# Altium schematic sheet display.SchDoc(display)
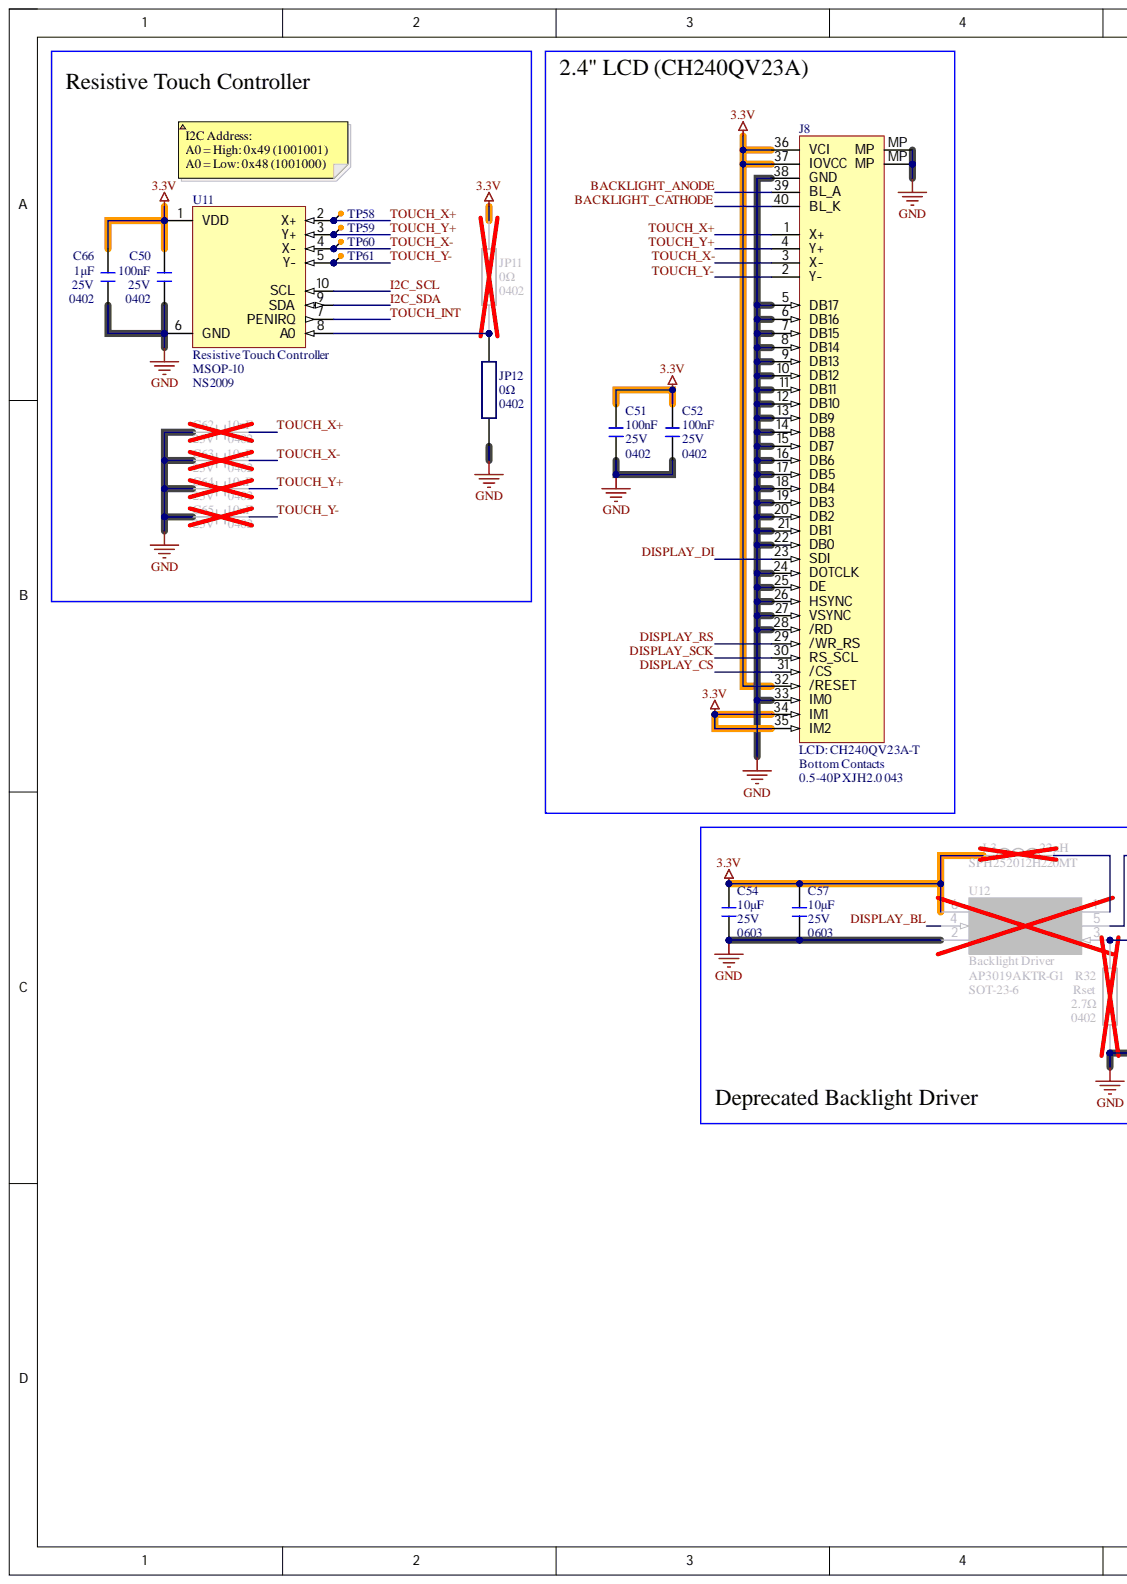
[diagram p0: display.SchDoc, left]
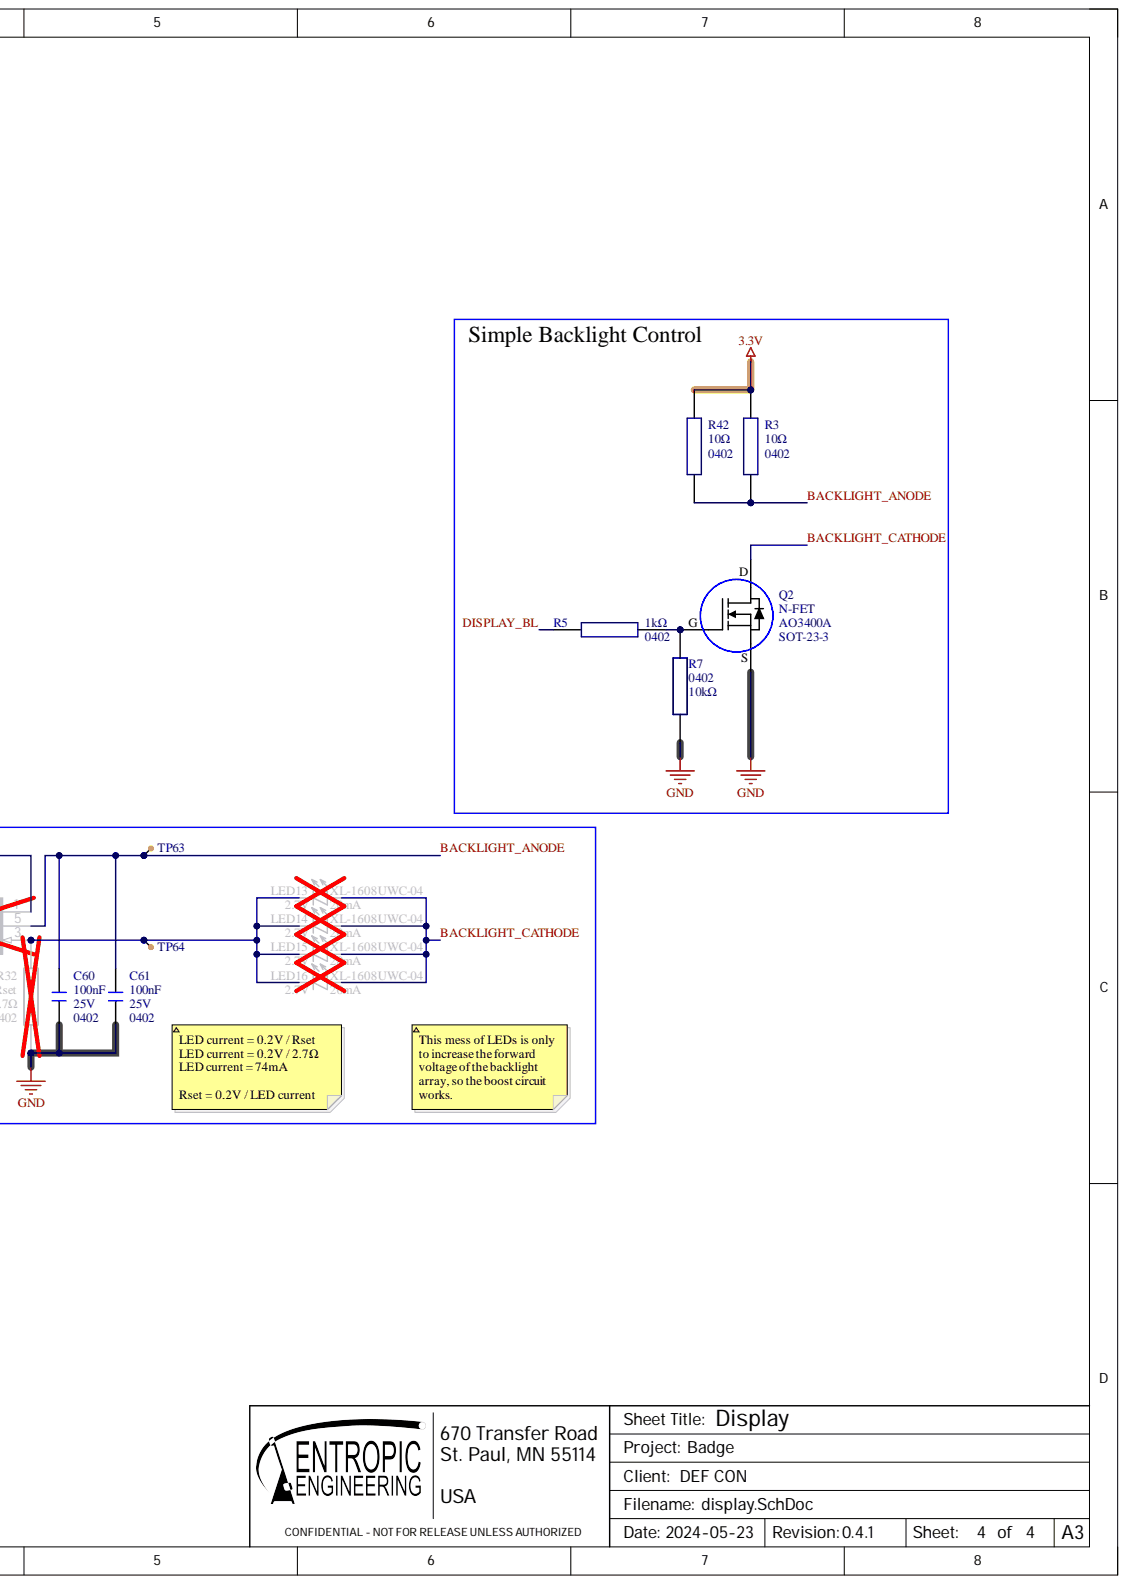
[diagram p1: display.SchDoc, right]

## Parts
C50  Capacitance: 100nF  Case Code (Imperial): 0402  Voltage: 25V  [0402_capacitor]  (hidden comment: GRM155R71E104KE14D)
C51  Capacitance: 100nF  Case Code (Imperial): 0402  Voltage: 25V  [0402_capacitor]  (hidden comment: GRM155R71E104KE14D)
C52  Capacitance: 100nF  Case Code (Imperial): 0402  Voltage: 25V  [0402_capacitor]  (hidden comment: GRM155R71E104KE14D)
C54  value 10µF  Case Code (Imperial): 0603  Voltage Rating: 25V  [0603_capacitor]  (hidden comment: CL10A106MA8NRNC)
C57  value 10µF  Case Code (Imperial): 0603  Voltage Rating: 25V  [0603_capacitor]  (hidden comment: CL10A106MA8NRNC)
C60  Capacitance: 100nF  Case Code (Imperial): 0402  Voltage: 25V  [0402_capacitor]  (hidden comment: GRM155R71E104KE14D)
C61  Capacitance: 100nF  Case Code (Imperial): 0402  Voltage: 25V  [0402_capacitor]  (hidden comment: GRM155R71E104KE14D)
C62  Capacitance: 10nF  Case Code (Imperial): 0402  Voltage Rating: 25V  [0402_capacitor]  (hidden comment: CL05B103KA5NNNC)
C63  Capacitance: 10nF  Case Code (Imperial): 0402  Voltage Rating: 25V  [0402_capacitor]  (hidden comment: CL05B103KA5NNNC)
C64  Capacitance: 10nF  Case Code (Imperial): 0402  Voltage Rating: 25V  [0402_capacitor]  (hidden comment: CL05B103KA5NNNC)
C65  Capacitance: 10nF  Case Code (Imperial): 0402  Voltage Rating: 25V  [0402_capacitor]  (hidden comment: CL05B103KA5NNNC)
C66  Capacitance: 1µF  Size Code: 0402  Voltage: 25V  [0402_capacitor]  (hidden comment: GRM155R61E105KA12D)
J8  LCD: CH240QV23A-T  contact_side: Bottom Contacts  [CH240QV23A-T]
JP11  value 0Ω  Case Code (Imperial): 0402  [0402_resistor]  (hidden comment: RC0402FR-070RL)
JP12  value 0Ω  Case Code (Imperial): 0402  [0402_resistor]  (hidden comment: RC0402FR-070RL)
L3  SPH252012H220MT  value 22µH  [INDC2520X12N]
LED13  XL-1608UWC-04  Current: 20mA  Forward Voltage: 2.7V  [0603_DIODE]
LED14  XL-1608UWC-04  Current: 20mA  Forward Voltage: 2.7V  [0603_DIODE]
LED15  XL-1608UWC-04  Current: 20mA  Forward Voltage: 2.7V  [0603_DIODE]
LED16  XL-1608UWC-04  Current: 20mA  Forward Voltage: 2.7V  [0603_DIODE]
Q2  N-FET  Case/Package: SOT-23-3  [SOT95P280X115-3N]
R3  value 10Ω  Case Code (Imperial): 0402  [0402_resistor]  (hidden comment: RC0402JR-0710RL)
R5  value 1kΩ  Case Code (Imperial): 0402  [0402_resistor]  (hidden comment: RC0402JR-071KL)
R7  value 10kΩ  Case Code (Imperial): 0402  [0402_resistor]  (hidden comment: RC0402FR-0710KL)
R32  Rset  value 2.7Ω  Case Code (Imperial): 0402  [0402_resistor]
R42  value 10Ω  Case Code (Imperial): 0402  [0402_resistor]  (hidden comment: RC0402JR-0710RL)
TP58  test_point-1  [test_point-1]  (value not drawn)  (no BOM)
TP59  test_point-1  [test_point-1]  (value not drawn)  (no BOM)
TP60  test_point-1  [test_point-1]  (value not drawn)  (no BOM)
TP61  test_point-1  [test_point-1]  (value not drawn)  (no BOM)
TP63  test_point-1  [test_point-1]  (value not drawn)  (no BOM)
TP64  test_point-1  [test_point-1]  (value not drawn)  (no BOM)
U11  Resistive Touch Controller  Case/Package: MSOP-10  [TSSOP50P490X112-10N]
U12  Backlight Driver  Case/Package: SOT-23-6  [SOT95P280X110-6N]

## Nets
3.3V: C50.2 C51.2 C52.2 C54.2 C57.2 C66.2 J8.32(/RESET) J8.34(IM1) J8.35(IM2) J8.36(VCI) J8.37(IOVCC) JP11.1 L3.1 R3.2 R42.2 U11.1(VDD) U12.6(VIN)
GND: C50.1 C51.1 C52.1 C54.1 C57.1 C60.1 C61.1 C62.1 C63.1 C64.1 C65.1 C66.1 J8.5(DB17) J8.6(DB16) J8.7(DB15) J8.8(DB14) J8.9(DB13) J8.10(DB12) J8.11(DB11) J8.12(DB10) J8.13(DB9) J8.14(DB8) J8.15(DB7) J8.16(DB6) J8.17(DB5) J8.18(DB4) J8.19(DB3) J8.20(DB2) J8.21(DB1) J8.22(DB0) J8.24(DOTCLK) J8.25(DE) J8.26(HSYNC) J8.27(VSYNC) J8.28(/RD) J8.33(IM0) J8.38(GND) J8.MP JP12.2 Q2.2 R7.2 R32.2 U11.6(GND) U12.2(GND)
BACKLIGHT_ANODE: C60.2 C61.2 J8.39(BL_A) R3.1 R42.1 TP63.1 U12.5(VOUT)
BACKLIGHT_CATHODE: J8.40(BL_K) LED13.2 LED14.2 LED15.2 LED16.2 Q2.3
DISPLAY_BL: R5.1 U12.4(CTRL)
DISPLAY_CS: J8.31(/CS)
DISPLAY_DI: J8.23(SDI)
DISPLAY_RS: J8.29(/WR_RS)
DISPLAY_SCK: J8.30(RS_SCL)
I2C_SCL: U11.10(SCL)
I2C_SDA: U11.9(SDA)
TOUCH_INT: U11.7(PENIRQ)
TOUCH_X+: C62.2 J8.1(X+) TP58.1 U11.2(X+)
TOUCH_X-: C63.2 J8.3(X-) TP60.1 U11.4(X-)
TOUCH_Y+: C64.2 J8.4(Y+) TP59.1 U11.3(Y+)
TOUCH_Y-: C65.2 J8.2(Y-) TP61.1 U11.5(Y-)
NetJP11_2: JP11.2 JP12.1 U11.8(A0)
NetL3_2: L3.2 U12.1(SW)
NetLED13_1: LED13.1 LED14.1 LED15.1 LED16.1 R32.1 TP64.1 U12.3(FB)
NetQ2_1: Q2.1 R5.2 R7.1

## Library data of the parts
C50-C52 C60 C61 = GRM155R71E104KE14D: 100 nF, 25 V, X7R, 0402 Chip capacitor; house_part_number: C-100nF-0402-002; Manufacturer: Murata; Dielectric Material: Ceramic; Tolerance: 10%; Case Code (Metric): 1005; Case/Package: 0402; Category: Ceramic Capacitors; Component Kind: Standard; ComponentLink1Description: http://www.farnell.com/datasheets/1872206.pdf?src-supplier=Farnell; ComponentLink1URL: http://www.farnell.com/datasheets/1872206.pdf?src-supplier=Farnell; ComponentLink2Description: http://components.arrow.com/part/dataview/0323_06361249?src-supplier=Arrow+Europe; ComponentLink2URL: http://components.arrow.com/part/dataview/0323_06361249?src-supplier=Arrow+Europe; ComponentLink3Description: http://uk.rs-online.com/webdocs/144c/0900766b8144c113.pdf?src-supplier=RSComponents; ComponentLink3URL: http://uk.rs-online.com/webdocs/144c/0900766b8144c113.pdf?src-supplier=RSComponents; ComponentLink4Description: http://www.farnell.com/datasheets/2048008.pdf?src-supplier=Farnell; ComponentLink4URL: http://www.farnell.com/datasheets/2048008.pdf?src-supplier=Farnell; ComponentLink5Description: http://www.mouser.com/ds/2/281/c02e-2905.pdf?src-supplier=Mouser; ComponentLink5URL: http://www.mouser.com/ds/2/281/c02e-2905.pdf?src-supplier=Mouser; ComponentLink6Description: http://www.farnell.com/datasheets/2048008.pdf?src-supplier=Newark; ComponentLink6URL: http://www.farnell.com/datasheets/2048008.pdf?src-supplier=Newark; ComponentLink7Description: https://search.murata.co.jp/Ceramy/image/img/A01X/G101/ENG/GRM155R71E104KE14-01.pdf?src-supplier=Digi-Key; ComponentLink7URL: https://search.murata.co.jp/Ceramy/image/img/A01X/G101/ENG/GRM155R71E104KE14-01.pdf?src-supplier=Digi-Key; ComponentLink8Description: http://www.farnell.com/datasheets/2048008.pdf?src-supplier=Element14; ComponentLink8URL: http://www.farnell.com/datasheets/2048008.pdf?src-supplier=Element14; ComponentLink9Description: http://psearch.en.murata.com/capacitor/product/GRM155R71E104KE14%23.pdf?src-supplier=Avnet; ComponentLink9URL: http://psearch.en.murata.com/capacitor/product/GRM155R71E104KE14%23.pdf?src-supplier=Avnet; Depth: 0.5mm; Dielectric: X7R; Height: 0.5mm; Lead Free: Lead Free; Length: 1mm; Manufacturer Part Number: GRM155R71E104KE14D; Max Operating Temperature: 125°C; Min Operating Temperature: -55°C; Mount: Surface Mount; Package Quantity: 10000; Packaging: Tape and Reel; Pricing 1: 1=0.10000, 10=0.02700, 50=0.01460, 100=0.01190, 500=0.00848, 1000=0.00666, 2500=0.00605, 5000=0.00557 (USD); REACH SVHC: Unknown; Resistor Type: Ceramic; RoHS: Yes; RoHS Compliant: Yes; Supplier 1: Digi-Key; Supplier Part Number 1: 490-10698-1-ND; Termination: SMD/SMT; Thickness: 0.022inch; Voltage Rating: 25V; Voltage Rating (DC): 25V; Weight: 0.000212oz; Width: 0.02inch; Library Name: defcon_badge.SchLib
C54 C57 = CL10A106MA8NRNC: 10 µF, 25 V, X5R, 0603 Chip capacitor; house_part_number: C-10uF-0603-002; Manufacturer: Samsung; Material: 4241ConductorMaterial; Tolerance: 20%; Case Code (Metric): 1608; Case/Package: 0603; Category: Ceramic Capacitors; Component Kind: Standard; ComponentLink1Description: https://datasheet.ciiva.com/25766/cl10a106ma8nrnc-25766562.pdf?src-supplier=Digikey; ComponentLink1URL: https://datasheet.ciiva.com/25766/cl10a106ma8nrnc-25766562.pdf?src-supplier=Digikey; Height: 900um; Lead Free: Lead Free; Manufacturer Part Number: CL10A106MA8NRNC; Max Operating Temperature: 85°C; Min Operating Temperature: -55°C; Mounting Technology: Surface Mount; Packaging: Cut Tape; Pricing: 4000=0.0511, 8000=0.046, 12000=0.0434, 28000=0.0413, 100000=0.0356 (USD); RoHS: Yes; RoHS Compliant: Yes; Series: CL; Stock: 632000; Supplier 1: Arrow Electronics; Supplier Part Number 1: CL10A106MA8NRNC; Library Name: defcon_badge.SchLib
C62-C65 = CL05B103KA5NNNC: 10 nF, 25 V, X7R, 0402 Chip capacitor; house_part_number: C-10nF-0402-002; Manufacturer: Samsung; Tolerance: 10%; Case Code (Metric): 1005; Case/Package: 0402; Category: Ceramic Capacitors; Component Kind: Standard; ComponentLink1Description: https://datasheet.ciiva.com/15195/getdatasheetpartid-358612-15195635.pdf?src-supplier=Verical; ComponentLink1URL: https://datasheet.ciiva.com/15195/getdatasheetpartid-358612-15195635.pdf?src-supplier=Verical; ComponentLink2Description: https://datasheet.ciiva.com/16740/cl05b104kq5nnnc-16740683.pdf?src-supplier=Future+Electronics; ComponentLink2URL: https://datasheet.ciiva.com/16740/cl05b104kq5nnnc-16740683.pdf?src-supplier=Future+Electronics; Construction: Flat; Contact Plating: Tin; Depth: 0.5mm; Dielectric: X7R; Height: 0.5mm; Length: 1mm; Manufacturer Part Number: CL05B103KA5NNNC; Max Operating Temperature: 125°C; Min Operating Temperature: -55°C; Mount: Surface Mount; Package Quantity: 1; Packaging: Tape and Reel; Pricing 1: 10000=0.00328, 20000=0.00312, 30000=0.00297, 50000=0.00266, 100000=0.00215, 250000=0.00195 (USD); Radiation Hardening: No; RoHS: Yes; RoHS Compliant: Yes; Series: CL; Stock 1: 2318300; Supplier 1: Digi-Key; Supplier Part Number 1: 1276-1057-2-ND; Thickness: 0.022inch; Width: 0.02inch; Library Name: defcon_badge.SchLib
C66 = GRM155R61E105KA12D: 1 µF, 25 V, X5R, 0402 Chip capacitor; house_part_number: C-1uF-0402-002; Manufacturer: Murata; Capacitor Type: Ceramic; Multilayer: Yes; Negative Tolerance: 10%; Category: Ceramic Capacitors; Component Kind: Standard; ComponentLink1Description: https://datasheet.ciiva.com:443/pdfs/VipMasterIC/IC/MURE/MURE-S-A0004362469/MURE-S-A0004364524-1.pdf?src-supplier=IHS+Markit; ComponentLink1URL: https://datasheet.ciiva.com:443/pdfs/VipMasterIC/IC/MURE/MURE-S-A0004362469/MURE-S-A0004364524-1.pdf?src-supplier=IHS+Markit; ComponentLink2Description: https://datasheet.ciiva.com/7719/1666664-7719006.pdf?src-supplier=Element14; ComponentLink2URL: https://datasheet.ciiva.com/7719/1666664-7719006.pdf?src-supplier=Element14; ComponentLink3Description: https://datasheet.ciiva.com/22732/1666664-22732122.pdf?src-supplier=Element14; ComponentLink3URL: https://datasheet.ciiva.com/22732/1666664-22732122.pdf?src-supplier=Element14; Dielectric Material: Ceramic; Height: 0.5mm; JESD-609 Code: e3; Length: 1mm; Manufacturer Part Number: GRM155R61E105KA12D; Max Operating Temperature: 85°C; Min Operating Temperature: -55°C; Mount: Surface Mount; Number of Pins: 2; Package Shape: Rectangular; Package Style: SMTMeter; Packaging: Tape and Reel; Positive Tolerance: 10%; Pricing 1: 1=0.11000, 10=0.08300, 50=0.04560, 100=0.03720, 500=0.02656, 1000=0.02087, 2500=0.01898, 5000=0.01746 (USD); REACH SVHC: Yes; RoHS: Yes; RoHS Compliant: Yes; Stock 1: 145965; Supplier 1: Digi-Key; Supplier Part Number 1: 490-10017-1-ND; Temperature Characteristics Code: X5R; Terminal Finish: Nickel; Termination: Wraparound; Width: 0.5mm; Library Name: defcon_badge.SchLib
J8 = 0.5-40P XJH2.0 043: 40-Pin, 0.5 mm pitch, bottom contact, flat flex connector for LCD CH240QV23A-T; Component Kind: Standard; house_part_number: 0.5-40P XJH2.0 043; LCD Part Number: CH240QV23A-T; Manufacturer Part Number: 0.5-40P XJH2.0 043; Supplier 1: LCSC; Supplier Part Number 1: C5373441; Library Name: defcon_badge.SchLib
JP11 JP12 = RC0402FR-070RL: 0 Ω, 0402 Chip resistor (jumper); house_part_number: R-0-0402-1%-001; Manufacturer: Yageo; Max Power Dissipation: 63mW; Power Rating: 62.5mW; Temperature Coefficient: 200ppm/°C; Tolerance: 1%; Voltage Rating: 50V; Case Code (Metric): 1005; Case/Package: 0402; Category: Chip SMD Resistors; Component Kind: Standard; Contact Plating: Tin,Matte; Features: Moisture Resistant; Height: 0.35mm; Lead Free: 5697LeadFree; Length: 1mm; Manufacturer Part Number: RC0402FR-070RL; Max Operating Temperature: 155°C; Min Operating Temperature: -55°C; Mounting Technology: Surface Mount; Number of Terminations: 2; Packaging: Tape and Reel; Pricing: 10000=0.00093 (USD); RoHS: Yes; RoHS Compliant: Yes; Series: RC; Stock: 580000; Supplier 1: Avnet; Supplier Part Number 1: RC0402FR-070RL; Termination: SMD/SMT; Width: 0.5mm; Library Name: defcon_badge.SchLib
L3 = SPH252012H220MT: 22 μH, .55A, 1008 Inductor; Manufacturer: Sunlord; Case/Package: 1008; Category: Fixed Inductors; Component Kind: Standard; Manufacturer Part Number: SPH252012H220MT; Pricing: 1=0.35, 10=0.303, 25=0.2776, 50=0.2524, 100=0.2247, 250=0.21208, 500=0.1818, 1000=0.1616 (USD); RoHS: No; RoHS Compliant: No; Stock: 945; Supplier: Digikey; Supplier Part Number: 3442-SPH252012H220MTCT-ND; Library Name: defcon_badge.SchLib
LED13-LED16 = XL-1608UWC-04: White LED, 2.7-3.0 V, 20 mA, 0603; Manufacturer: XINGLIGHT; Component Kind: Standard; Manufacturer Part Number: XL-1608UWC-04; Pricing 1: 100=0.0055, 1000=0.0044, 4000=0.0035, 8000=0.0032, 48000=0.0028, 100000=0.0027 (USD); RoHS: No; Stock 1: 1278300; Supplier 1: LCSC; Supplier Part Number 1: C965808; Library Name: defcon_badge.SchLib
Q2 = AO3400A: N-FET, 30V, 5.7A, SOT-23-3; Manufacturer: Alpha & Omega Semiconductor; Drain to Source Resistance: 18mR; Input Capacitance: 630pF; Max Power Dissipation: 1.4W; Number of Channels: 1NUMBER; Rds On Max: 26.5mR; Threshold Voltage: 650mV; Turn-Off Delay Time: 25ns; Turn-On Delay Time: 3ns; Category: MOSFETs; Component Kind: Standard; ComponentLink1Description: https://datasheet.ciiva.com/940/ao3400a-940739.pdf?src-supplier=Digikey; ComponentLink1URL: https://datasheet.ciiva.com/940/ao3400a-940739.pdf?src-supplier=Digikey; ComponentLink2Description: https://datasheet.ciiva.com/26906/getdatasheetpartid-64438-26906526.pdf?src-supplier=Verical; ComponentLink2URL: https://datasheet.ciiva.com/26906/getdatasheetpartid-64438-26906526.pdf?src-supplier=Verical; ComponentLink3Description: https://datasheet.ciiva.com/940/ao3400a-940740.pdf?src-supplier=Digikey; ComponentLink3URL: https://datasheet.ciiva.com/940/ao3400a-940740.pdf?src-supplier=Digikey; ComponentLink4Description: https://datasheet.ciiva.com/940/ao3400a-940741.pdf?src-supplier=Digikey; ComponentLink4URL: https://datasheet.ciiva.com/940/ao3400a-940741.pdf?src-supplier=Digikey; Continuous Drain Current (ID): 5.7A; Drain to Source Breakdown Voltage: 30V; Drain to Source Voltage (Vdss): 30V; Height: 1.25mm; house_part_number: AO3400A; Lead Free: Lead Free; Manufacturer Part Number: AO3400A; Max Operating Temperature: 150°C; Min Operating Temperature: -55°C; Mounting Technology: Surface Mount; Packaging: Cut Tape; Pricing: 3000=0.0899, 6000=0.0869, 9000=0.0763, 30000=0.0746, 75000=0.0627 (USD); RoHS: Yes; RoHS Compliant: Yes; Series: -; Stock: 141000; Supplier: Arrow Electronics; Supplier Part Number 1: AO3400A; Library Name: defcon_badge.SchLib
R3 R42 = RC0402JR-0710RL: 10 Ω, 0402 Chip resistor; house_part_number: R-10-0402-1%-001; Manufacturer: Yageo; Max Power Dissipation: 63mW; Power Rating: 62.5mW; Resistance Tolerance: 5%; Temperature Coefficient: 200ppm/°C; Voltage Rating: 50V; Case Code (Metric): 1005; Case/Package: 0402; Category: Chip SMD Resistors; Component Kind: Standard; ComponentLink1Description: https://datasheet.ciiva.com/7983/1018594-7983543.pdf?src-supplier=Element14; ComponentLink1URL: https://datasheet.ciiva.com/7983/1018594-7983543.pdf?src-supplier=Element14; ComponentLink2Description: https://datasheet.ciiva.com/7850/1018594-7850138.pdf?src-supplier=Element14; ComponentLink2URL: https://datasheet.ciiva.com/7850/1018594-7850138.pdf?src-supplier=Element14; ComponentLink3Description: https://datasheet.ciiva.com/7850/1018594-7850139.pdf?src-supplier=Element14; ComponentLink3URL: https://datasheet.ciiva.com/7850/1018594-7850139.pdf?src-supplier=Element14; ComponentLink4Description: https://datasheet.ciiva.com/2452/pyu-r-int-thick-7-2452632.pdf?src-supplier=Digikey; ComponentLink4URL: https://datasheet.ciiva.com/2452/pyu-r-int-thick-7-2452632.pdf?src-supplier=Digikey; ComponentLink5Description: https://datasheet.ciiva.com/2452/pyu-r-int-thick-7-2452633.pdf?src-supplier=Digikey; ComponentLink5URL: https://datasheet.ciiva.com/2452/pyu-r-int-thick-7-2452633.pdf?src-supplier=Digikey; ComponentLink6Description: https://datasheet.ciiva.com/2452/pyu-r-int-thick-7-2452634.pdf?src-supplier=Digikey; ComponentLink6URL: https://datasheet.ciiva.com/2452/pyu-r-int-thick-7-2452634.pdf?src-supplier=Digikey; ComponentLink7Description: https://datasheet.ciiva.com/16741/pyu-rc0402-51-rohs-l-6-r-16741085.pdf?src-supplier=Future+Electronics; ComponentLink7URL: https://datasheet.ciiva.com/16741/pyu-rc0402-51-rohs-l-6-r-16741085.pdf?src-supplier=Future+Electronics; Construction: Rectangular; Depth: 0.5mm; Features: Moisture Resistant; Height: 0.016inch; Lead Free: Lead Free; Length: 0.039inch; Manufacturer Part Number: RC0402JR-0710RL; Max Operating Temperature: 155°C; Military Standard: Not; Min Operating Temperature: -55°C; Number of Terminations: 2; Package Quantity: 1; Packaging: Tape and Reel; Pricing: 10000=0.0011, 50000=0.001, 200000=0.001, 500000=0.001, 1250000=0.0009 (USD); Reach SVHC Compliant: No; Resistor Type: Thick Film; RoHS: Yes; RoHS Compliant: Yes; Series: RC; Stock: 60000; Supplier 1: Future Electronics; Supplier Part Number 1: 4524278; Technology: THICK FILM; Termination: SMD/SMT; Tolerance: 5%; Voltage Rating (AC): 50V; Width: 0.02inch; Working Voltage: 50V; Library Name: defcon_badge.SchLib
R5 = RC0402JR-071KL: 1 kΩ, 0402 Chip resistor; house_part_number: R-1k-0402-1%-001; Manufacturer: Yageo; Max Power Dissipation: 63mW; Power Rating: 62.5mW; Resistance Tolerance: 5%; Temperature Coefficient: 100ppm/°C; Voltage Rating: 50V; Case Code (Metric): 1005; Case/Package: 0402; Category: Chip SMD Resistors; Component Kind: Standard; ComponentLink1Description: https://datasheet.ciiva.com/7983/1018594-7983558.pdf?src-supplier=Element14; ComponentLink1URL: https://datasheet.ciiva.com/7983/1018594-7983558.pdf?src-supplier=Element14; ComponentLink2Description: https://datasheet.ciiva.com/2452/pyu-r-int-thick-7-2452756.pdf?src-supplier=Digikey; ComponentLink2URL: https://datasheet.ciiva.com/2452/pyu-r-int-thick-7-2452756.pdf?src-supplier=Digikey; ComponentLink3Description: https://datasheet.ciiva.com/2452/pyu-r-int-thick-7-2452757.pdf?src-supplier=Digikey; ComponentLink3URL: https://datasheet.ciiva.com/2452/pyu-r-int-thick-7-2452757.pdf?src-supplier=Digikey; ComponentLink4Description: https://datasheet.ciiva.com/2452/pyu-r-int-thick-7-2452755.pdf?src-supplier=Digikey; ComponentLink4URL: https://datasheet.ciiva.com/2452/pyu-r-int-thick-7-2452755.pdf?src-supplier=Digikey; ComponentLink5Description: https://datasheet.ciiva.com/7850/1018594-7850170.pdf?src-supplier=Element14; ComponentLink5URL: https://datasheet.ciiva.com/7850/1018594-7850170.pdf?src-supplier=Element14; ComponentLink6Description: https://datasheet.ciiva.com/7850/1018594-7850171.pdf?src-supplier=Element14; ComponentLink6URL: https://datasheet.ciiva.com/7850/1018594-7850171.pdf?src-supplier=Element14; ComponentLink7Description: https://datasheet.ciiva.com/17081/pyu-rc0402-51-rohs-l-6-r-17081438.pdf?src-supplier=Future+Electronics; ComponentLink7URL: https://datasheet.ciiva.com/17081/pyu-rc0402-51-rohs-l-6-r-17081438.pdf?src-supplier=Future+Electronics; Features: Moisture Resistant; Height: 0.016inch; Lead Free: 5697LeadFree; Length: 0.039inch; Manufacturer Part Number: RC0402JR-071KL; Max Operating Temperature: 155°C; Min Operating Temperature: -55°C; Number of Terminations: 2; Package Quantity: 1; Packaging: Cut Tape; Pricing: 1=0.1, 10=0.018, 50=0.0102, 100=0.0083, 500=0.00528, 1000=0.00444, 5000=0.00307 (USD); Reach SVHC Compliant: No; Resistor Type: Thick Film; RoHS: Yes; RoHS Compliant: Yes; Series: RC; Stock: 15490862; Supplier 1: Digikey; Supplier Part Number 1: 311-1.0KJRCT-ND; Termination: SMD/SMT; Tolerance: 5%; Voltage Rating (AC): 50V; Width: 0.02inch; Library Name: defcon_badge.SchLib
R7 = RC0402FR-0710KL: 10 kΩ, 0402 Chip resistor; house_part_number: R-10k-0402-1%-001; Manufacturer: Yageo; Max Power Dissipation: 63mW; Power Rating: 62.5mW; Resistance Tolerance: 1%; Temperature Coefficient: 100ppm/°C; Voltage Rating: 50V; Case Code (Metric): 1005; Case/Package: 0402; Category: Chip SMD Resistors; Component Kind: Standard; ComponentLink1Description: https://datasheet.ciiva.com/12667/pyu-rc0402-51-rohs-l-6-r-12667067.pdf?src-supplier=Mouser; ComponentLink1URL: https://datasheet.ciiva.com/12667/pyu-rc0402-51-rohs-l-6-r-12667067.pdf?src-supplier=Mouser; Construction: Rectangular; Depth: 0.5mm; Features: Moisture Resistant; Lead Free: 5697LeadFree; Lead Pitch: 0.2mm; Length: 1mm; Manufacturer Part Number: RC0402FR-0710KL; Max Operating Temperature: 155°C; Military Standard: Not; Min Operating Temperature: -55°C; Number of Terminations: 2; Packaging: Tape and Reel; Pins: 2; Pricing: 1=0.1, 10=0.015, 50=0.0088, 100=0.0073, 500=0.00488, 1000=0.00417, 5000=0.00297 (USD); Reach SVHC Compliant: Unknown; Resistor Type: Thick Film; RoHS: Yes; RoHS Compliant: Yes; Series: RC; Stock: 9380606; Supplier 1: Digikey; Supplier Part Number 1: 311-10.0KLRCT-ND; Termination: SMD/SMT; Tolerance: 1%; Voltage Rating (DC): 50V; Width: 0.5mm; Library Name: defcon_badge.SchLib
R32 = RC0402FR-072R7L: 2.7 Ω, 0402 Chip resistor; Manufacturer: Yageo; Max Power Dissipation: 63mW; Resistance Tolerance: 1%; Temperature Coefficient: 200ppm/°C; Voltage Rating: 50V; Case Code (Metric): 1005; Case/Package: 0402; Category: Chip SMD Resistors; Component Kind: Standard; ComponentLink1Description: https://datasheet.ciiva.com/7849/1018594-7849948.pdf?src-supplier=Element14; ComponentLink1URL: https://datasheet.ciiva.com/7849/1018594-7849948.pdf?src-supplier=Element14; ComponentLink2Description: https://datasheet.ciiva.com/7849/1018594-7849949.pdf?src-supplier=Element14; ComponentLink2URL: https://datasheet.ciiva.com/7849/1018594-7849949.pdf?src-supplier=Element14; Features: Moisture Resistant; Height: 0.016inch; Lead Free: Lead Free; Length: 0.039inch; Manufacturer Part Number: RC0402FR-072R7L; Max Operating Temperature: 155°C; Min Operating Temperature: -55°C; Number of Terminations: 2; Packaging: Tape and Reel; Pins: 2; Power Rating: 63mW; Pricing 1: 10000=0.00384, 20000=0.00341, 30000=0.00318, 50000=0.00294, 100000=0.00266 (USD); Reach SVHC Compliant: No; Resistor Type: Thick Film; RoHS: Yes; RoHS Compliant: Yes; Series: RC; Stock 1: 10000; Supplier 1: Digikey; Supplier Part Number 1: 13-RC0402FR-072R7LTR-ND; Termination: SMD/SMT; Tolerance: 1%; Voltage Rating (DC): 50V; Width: 0.5mm; Library Name: defcon_badge.SchLib
TP58-TP61 TP63 TP64 = test_point-1: Test point; pickable: no; Library Name: defcon_badge.SchLib
U11 = NS2009: Manufacturer: NSIWAY; Component Kind: Standard; Description: Resistive Touch Controller; house_part_number: NS2009; Manufacturer Part Number: NS2009; Supplier 1: LCSC; Supplier Part Number 1: C219024; Library Name: defcon_badge.SchLib
U12 = AP3019AKTR-G1: AP3019A Series 16 V 550 mA 1.2 MHz White LED Step-UP Converter - SOT-23-6; Manufacturer: Diodes; Dimming: PWM; Frequency: 1.2MHz; Max Output Voltage: 38V; Max Supply Current: 3.2mA; Max Supply Voltage: 16V; Min Input Voltage: 2.5V; Number of Channels: 1; Operating Frequency: 1.2 MHz; Output Current: 150mA; Output Current per Channel: 550mA; Topology: Boost; Category: LED Drivers; Component Kind: Standard; ComponentLink1Description: https://datasheet.ciiva.com/29602/ap3019a-29602771.pdf?src-supplier=Digikey; ComponentLink1URL: https://datasheet.ciiva.com/29602/ap3019a-29602771.pdf?src-supplier=Digikey; Manufacturer Part Number: AP3019AKTR-G1; Max Operating Temperature: 85°C; Min Operating Temperature: -40°C; Mounting Technology: Surface Mount; Number of Outputs: 1; Package Quantity: 3000; Packaging: Tape and Reel; Pins: 6; Pricing: 3000=0.155, 9000=0.151, 12000=0.15, 30000=0.146, 45000=0.142 (USD); RoHS: Yes; RoHS Compliant: Yes; Series: -; Stock: 3000; Supplier: Future Electronics; Supplier Part Number 1: 8048337; Switching Frequency: 1.2MHz; Weight: 0.000529oz; Library Name: defcon_badge.SchLib
